# Altium schematic sheet Diode Controllers.SchDoc
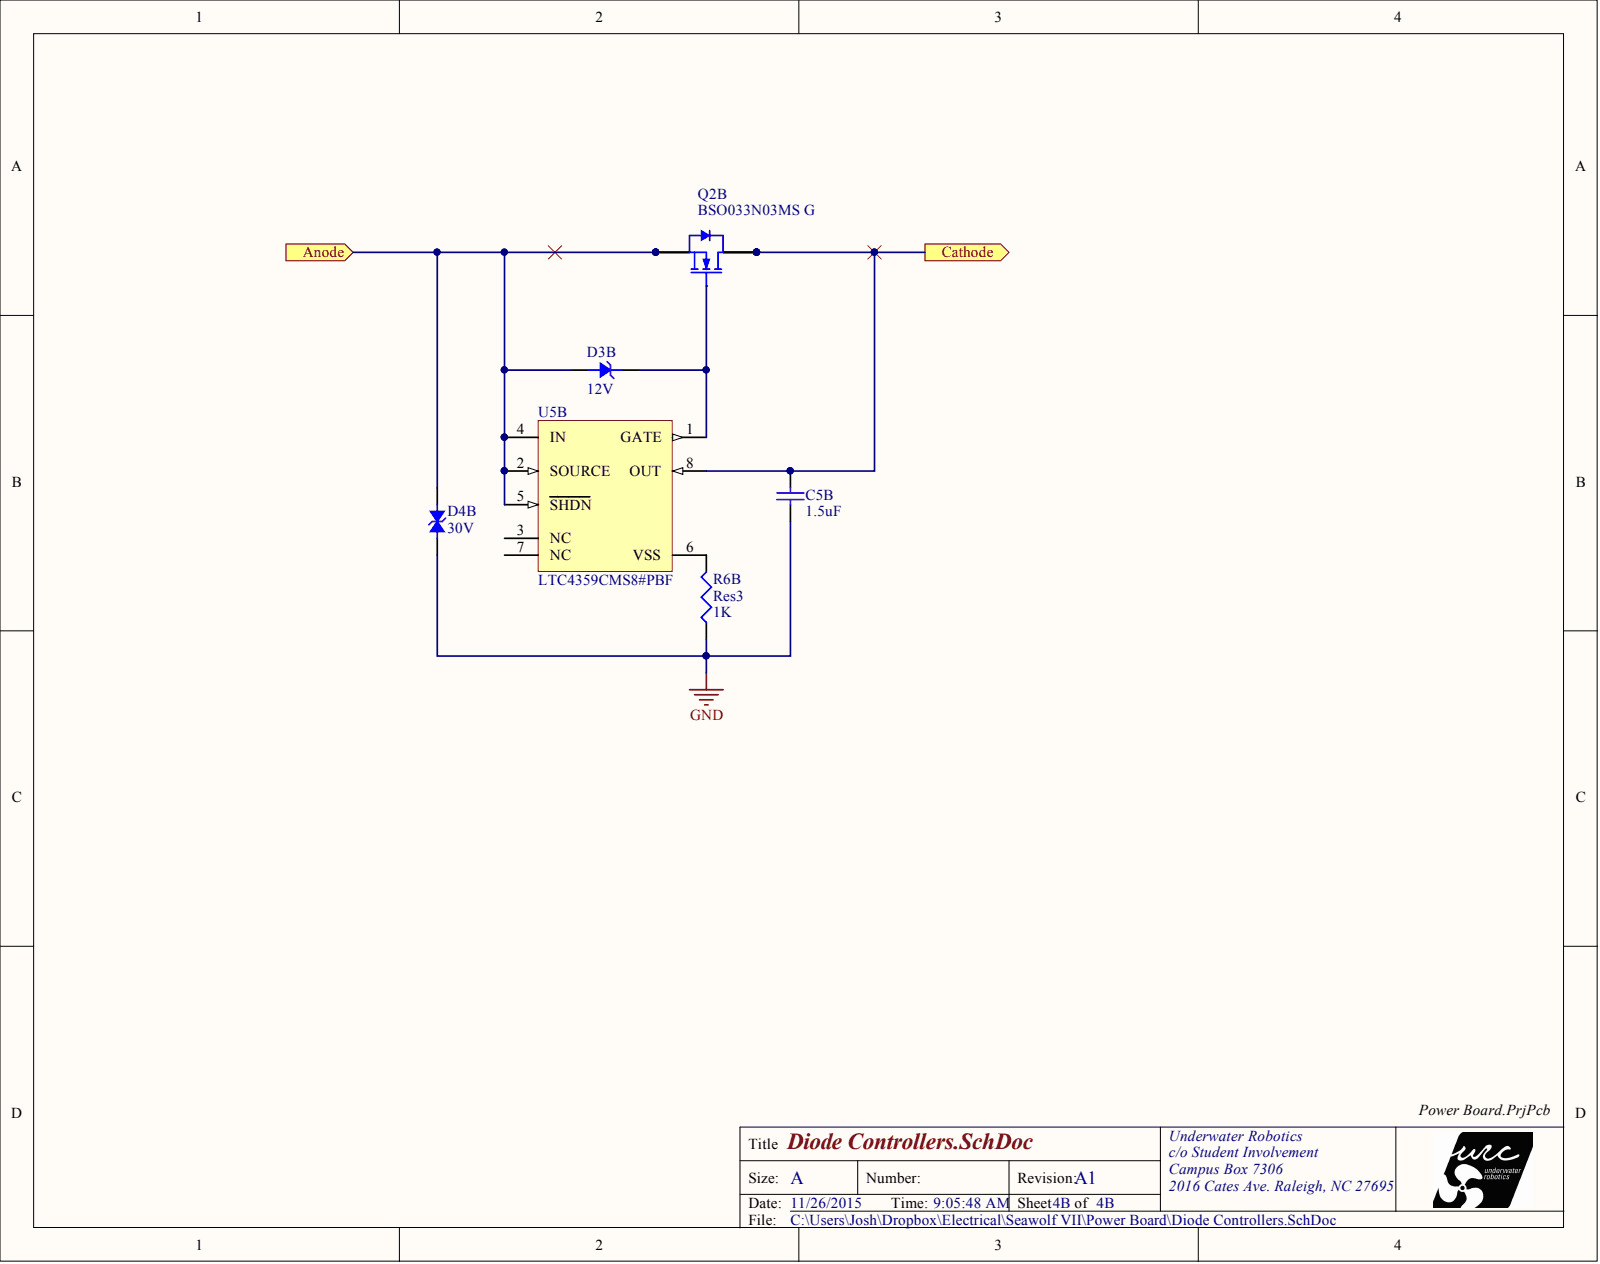
[diagram p0: Diode Controllers.SchDoc]

## Parts
C5B  value 1.5uF  [C0603]  (hidden comment: Cap)
D3B  Voltage - Zener (Nom) (Vz): 12V  [ONSC-SOD-523-2-502-01_C_V]  (hidden comment: DDZ9699T-7 Zener)
D4B  Voltage - Reverse Standoff (Typ): 30V  [ONSC-SMA-2-403D-02_C_V]  (hidden comment: Bidirectional Zener)
Q2B  BSO033N03MS G  [INF-PG-DSO-8-3_N]
R6B  Res3  value 1K  [J1-0603]
U5B  LTC4359CMS8#PBF  [LT-MS8-8_N]

## Ports
ANODE, CATHODE

## Nets
GND: C5B.2 D4B.1 R6B.1
NetC5B_1: C5B.1 Q2B.5 Q2B.6 Q2B.7 Q2B.8 U5B.8(OUT) ; port CATHODE
NetD3B_1: D3B.1 D4B.2 Q2B.1 Q2B.2 Q2B.3 U5B.2(SOURCE) U5B.4(IN) U5B.5(SHDN) ; port ANODE
NetD3B_2: D3B.2 Q2B.4 U5B.1(GATE)
NetR6B_2: R6B.2 U5B.6(VSS)

Not connected: U5B 3(NC) 7(NC)

## Library data of the parts
C5B = Cap: Unpolarized Capacitor; Component Kind: Standard; Library Name: URC_Lib.IntLib
D3B = DDZ9699T-7 Zener: Zener diode; Manufacturer: Diodes Incorporated; Current - Reverse Leakage @ Vr: 50nA @ 9.1V; Power - Max: 150mW; Tolerance: ±5%; Voltage - Forward (Vf) (Max) @ If: 900mV @ 10mA; Category: Discrete Semiconductor Products; Component Kind: Standard; ComponentLink1Description: http://www.diodes.com/_files/datasheets/ds30553.pdf; ComponentLink1URL: http://www.diodes.com/_files/datasheets/ds30553.pdf; Impedance (Max) (Zzt): -; Manufacturer Part Number: DDZ9699T-7; Mounting Type: Surface Mount; Operating Temperature: -65°C ~ 150°C; Package / Case: SC-79, SOD-523; Packaging: Tape & Reel (TR); Pricing 1: 3000=0.08 (USD); RoHS: RoHS Compliant; Stock 1: 0; Supplier 1: Digi-Key; Supplier Device Package: SOD-523; Supplier Part Number 1: DDZ9699T-7-ND; Library Name: URC_Lib.IntLib
D4B = SMAJ28CA Zener: Bidirectional Zener diode; Manufacturer: Littelfuse Inc.; Manufacturer Part Number: SMAJ24A; Current - Peak Pulse (10/1000µs): 10.3A; Power - Peak Pulse: 400W; Power Line Protection: No; Unidirectional Channels: 1; Voltage - Breakdown (Min): 26.7V; Voltage - Clamping (Max) @ Ipp: 38.9V; Applications: General Purpose; Bidirectional Channels: -; Capacitance @ Frequency: -; Category: Circuit Protection; Component Kind: Standard; ComponentLink1Description: http://www.littelfuse.com/~/media/electronics/datasheets/tvs_diodes/littelfuse_tvs_diode_smaj_datasheet.pdf.pdf; ComponentLink1URL: http://www.littelfuse.com/~/media/electronics/datasheets/tvs_diodes/littelfuse_tvs_diode_smaj_datasheet.pdf.pdf; Mounting Type: Surface Mount; Operating Temperature: -55°C ~ 150°C (TJ); Package / Case: DO-214AC, SMA; Packaging: Digi-Reel®; Pricing 1: 1=0.43, 10=0.36, 25=0.3156, 100=0.2701, 250=0.23424, 500=0.1984, 1000=0.15295, 2500=0.13984 (USD); Pricing 2: 1=0.47, 10=0.399, 25=0.35, 100=0.2994, 250=0.25968, 500=0.21996, 1000=0.16958, 2500=0.15504 (USD); RoHS: RoHS Compliant; Stock 1: 6754; Stock 2: 38304; Supplier 1: Digi-Key; Supplier 2: Digi-Key; Supplier Device Package: DO-214AC (SMA); Supplier Part Number 1: SMAJ24ALFDKR-ND; Supplier Part Number 2: SMAJ30CALFCT-ND; Type: Zener; Library Name: URC.SchLib
Q2B = BSO033N03MS G: N-Channel OptiMOS 3 M-Series Power-MOSFET, 30 V VDS, 22 A ID, -55 to 150 degC, PG-DSO-8-16, Reel, Green; Manufacturer: Infineon; Green: TRUE; ID (max): 22 A; IDpuls (max): 154 A; Polarity: N; Ptot (max): 2.5 W; QG: 93 nC; RDS (on) (max): 3.3 mOhm; RDS (on) (max) (@4.5V): 3.8 mOhm; Rth: 35 K/W; RthJA (max): 150 K/W; VDS (max): 30 V; VGS(th) (max): 2 V; VGS(th) (min): 1 V; Component Kind: Standard; ComponentLink1Description: Manufacturer URL; ComponentLink1URL: http://www.infineon.com/; ComponentLink2Description: Datasheet; ComponentLink2URL: http://www.infineon.com/dgdl/BSO033N03MS_rev1.1.pdf?folderId=db3a304313d846880113d91d60c500c4&fileId=db3a30431b0626df011b0d47b2e87dc5; ComponentLink3Description: Package Specification; ComponentLink3URL: http://www.infineon.com/cms/packages/SMD_-_Surface_Mounted_Devices/P-PG-DSO/P-PG-DSO-08-03x_-6x_-7x_-8x_-10x_-13x_-15x_-18x_-19x_-20x_-23.html?__locale=en; DatasheetVersion: Rev. 1.1; Halogen-free: TRUE; Library Name: Infineon Power MOSFET.SchLib; Mounting Technology: Surface Mount; PackageDescription: 8-Pin Plastic (Green) Dual Small Outline Package, 4.9 x 3.9 mm Body, 1.27 mm Pitch; PackageReference: PG-DSO-8-3; Packing: Reel; PartNumber: BSO033N03MS G; Supplier 1: Mouser; Supplier 2: Newark; Supplier 3: Farnell; Supplier 4: Digi-Key; Supplier 5: Digi-Key; Supplier 6: Digi-Key; Supplier Part Number 1: 726-BSO033N03MSG; Supplier Part Number 2: 47W3322; Supplier Part Number 3: 2212862; Supplier Part Number 4: BSO033N03MS GINTR-ND; Supplier Part Number 5: BSO033N03MS GINCT-ND; Supplier Part Number 6: BSO033N03MS GINDKR-ND
R6B = Res3: Resistor; Component Kind: Standard; LatestRevisionDate: 29-May-2009; LatestRevisionNote: IPC-7351 Footprint Added.; Library Name: Miscellaneous Devices.IntLib; PackageDescription: Chip Resistor; PackageReference: J1-0603; Published: 8-Jun-2000; Signal Integrity: Res; Simulation: RESISTOR; Publisher: Altium Limited
U5B = LTC4359CMS8#PBF: Ideal Diode Controller with Reverse Input Protection, 4 to 80 V Vin, 8-pin SOP (MS8-8), 0 to 70 degC, Pb-Free; Manufacturer: Linear Technology; Ideal Diode: Single, External, N-Channel; Component Kind: Standard; ComponentLink1Description: Manufacturer URL; ComponentLink1URL: http://www.linear.com/; ComponentLink2Description: Datasheet; ComponentLink2URL: http://cds.linear.com/docs/en/datasheet/4359f.pdf; ComponentLink3Description: Package Specification; ComponentLink3URL: http://cds.linear.com/docs/en/packaging/05081660_G_MS8.pdf; Library Name: Linear Technology Power Management Power Control.IntLib; Mounting Technology: Surface Mount; PackageDescription: 8-Pin Plastic MSOP, Body 3 x 3 mm, Pitch 0.65 mm; PackageReference: MS8-8; PackageVersion: Rev. G, 03/2012; PartNumber: LTC4359CMS8#PBF; RoHS: TRUE; Supplier 1: Digi-Key; Supplier 2: Newark; Supplier Part Number 1: LTC4359CMS8#PBF-ND; Supplier Part Number 2: 94T1309
